# Altium schematic sheet MCU_V2.SchDoc(MCU_V2)
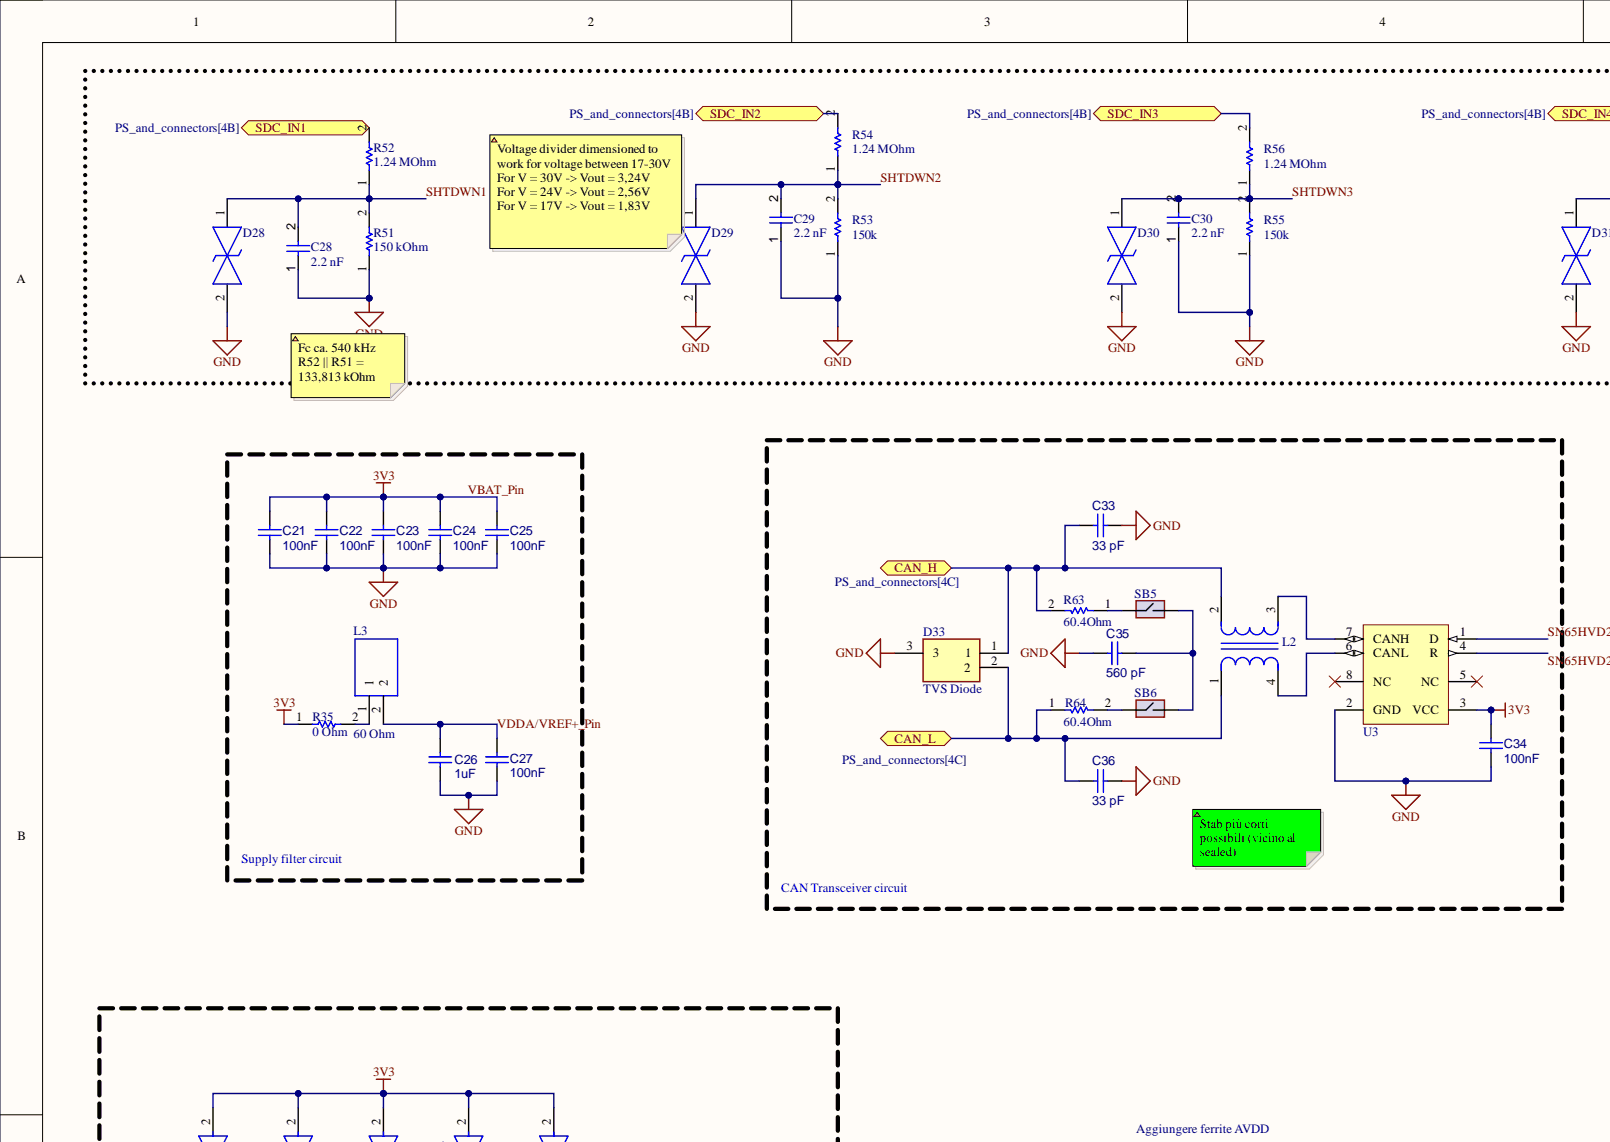
[diagram p0: MCU_V2.SchDoc, top left]
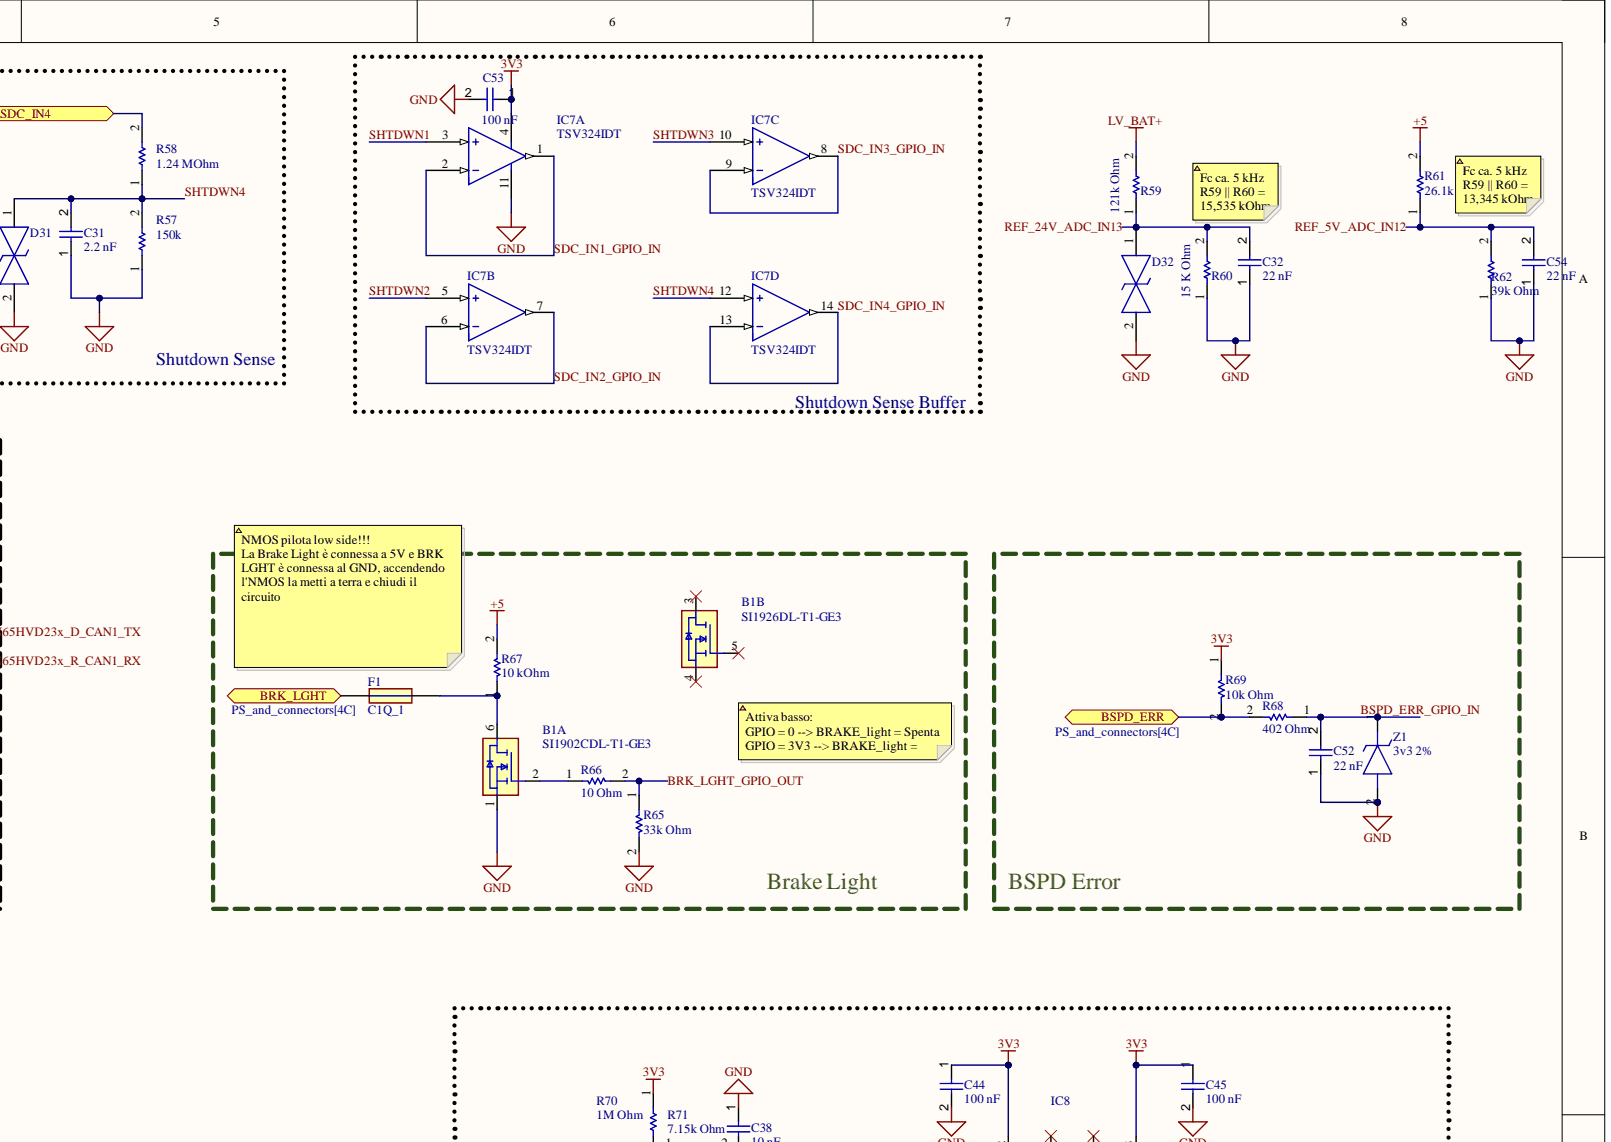
[diagram p1: MCU_V2.SchDoc, top right]
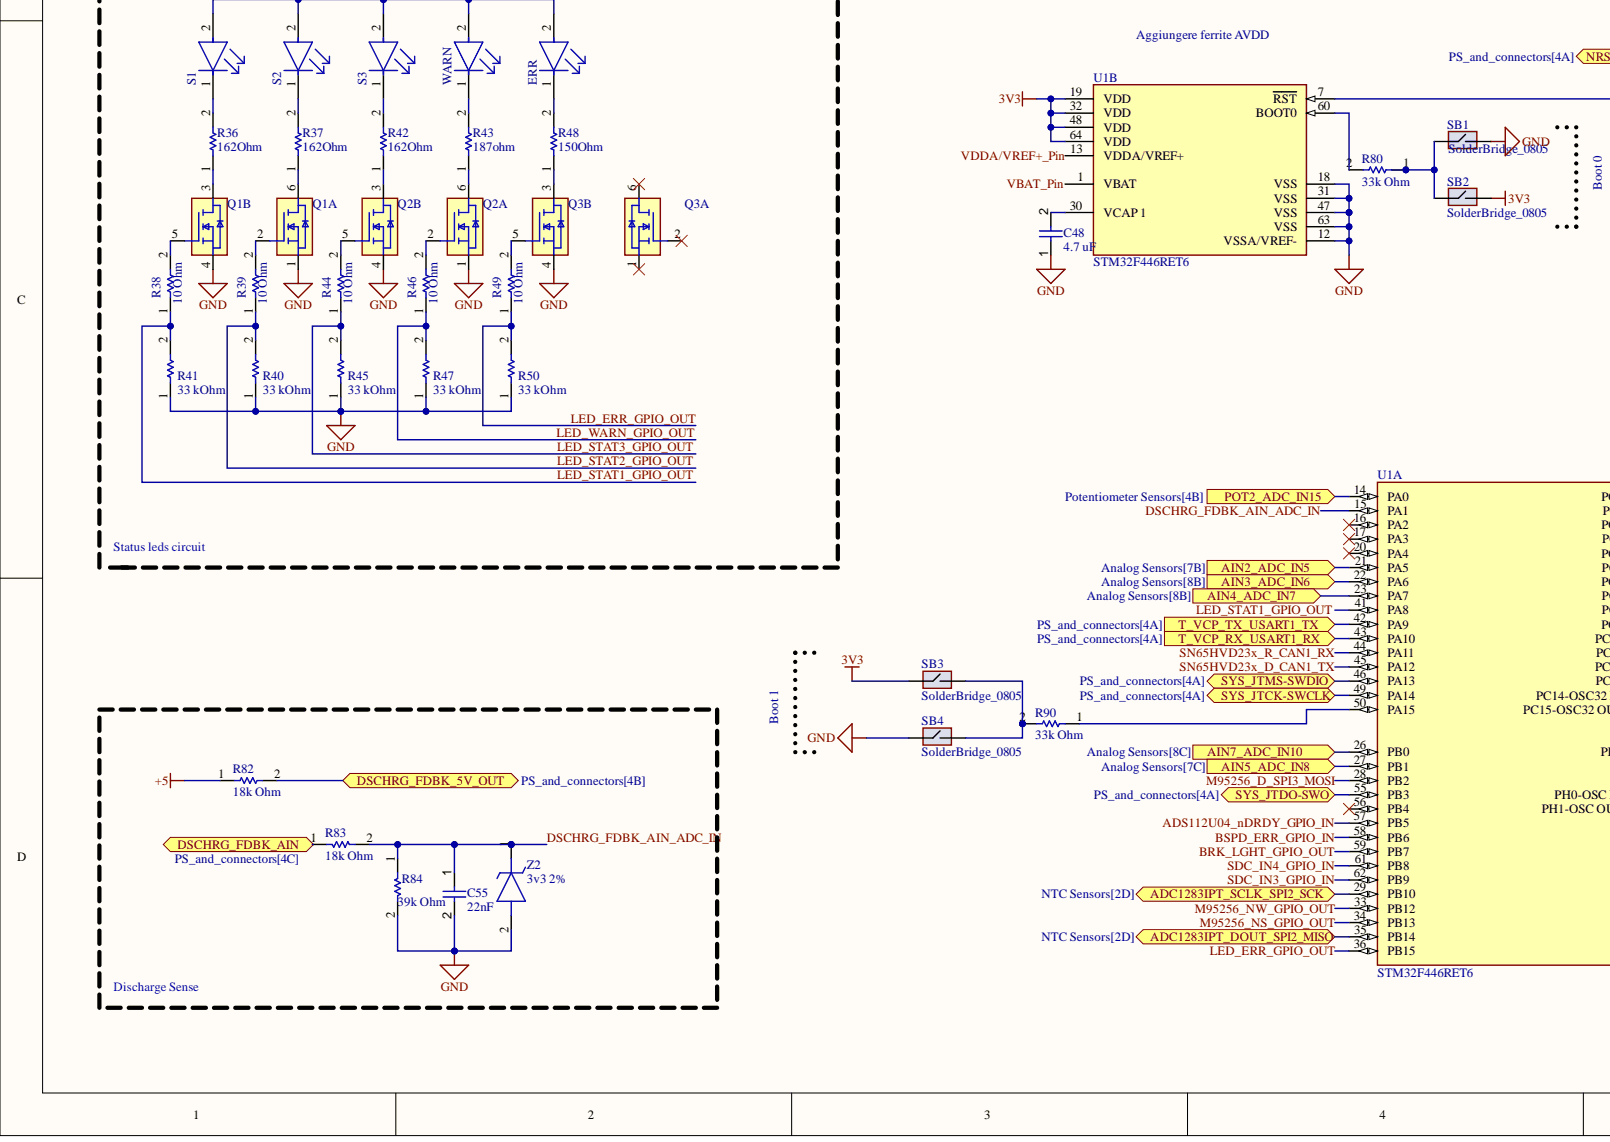
[diagram p2: MCU_V2.SchDoc, bottom left]
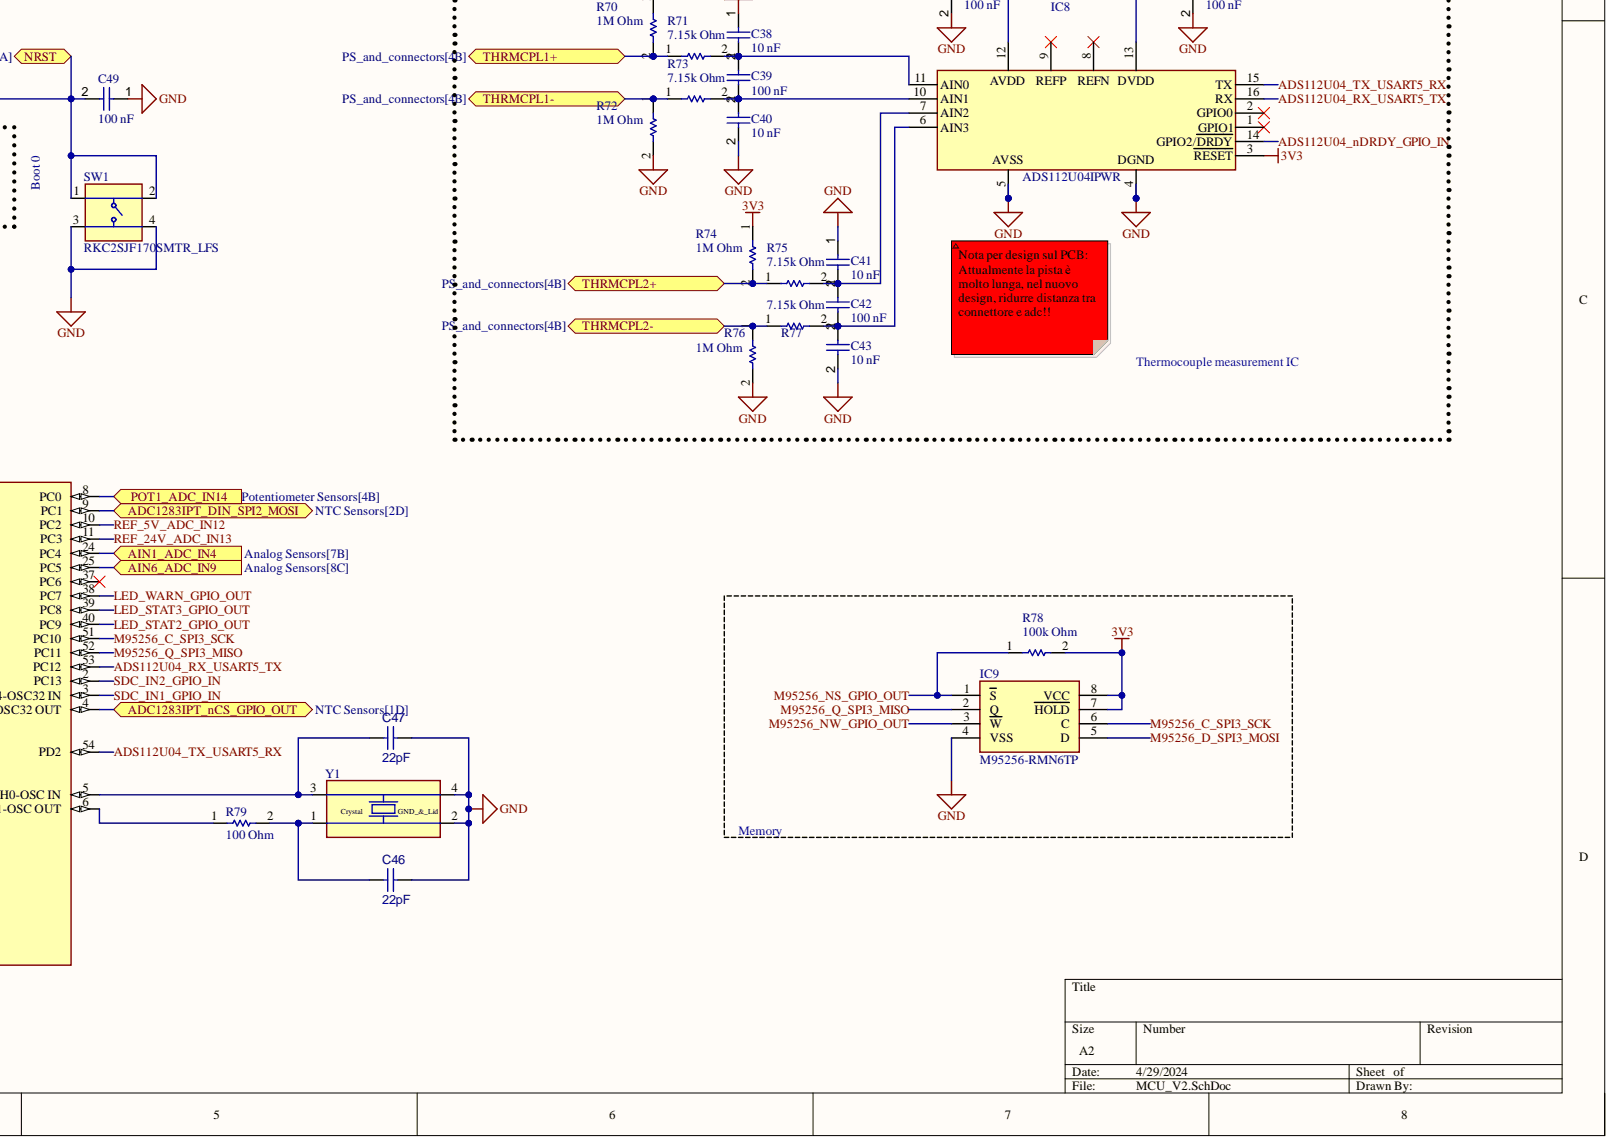
[diagram p3: MCU_V2.SchDoc, bottom right]

## Parts
B1  SI1902CDL-T1-GE3  Arrow Part Number: SI1926DL-T1-GE3  (parts A,B)
C21  100nF
C22  100nF
C23  100nF
C24  100nF
C25  100nF
C26  1uF
C27  100nF
C28  2.2 nF
C29  2.2 nF
C30  2.2 nF
C31  2.2 nF
C32  22 nF
C33  33 pF
C34  100nF
C35  560 pF
C36  33 pF
C38  10 nF
C39  100 nF
C40  10 nF
C41  10 nF
C42  100 nF
C43  10 nF
C44  100 nF
C45  100 nF
C46  22pF
C47  22pF
C48  4.7 uF
C49  100 nF
C52  22 nF
C53  100 nF
C54  22 nF
C55  22nF
D28  SMAJ30CA-TR  (value not drawn)
D29  SMAJ30CA-TR  (value not drawn)
D30  SMAJ30CA-TR  (value not drawn)
D31  SMAJ30CA-TR  (value not drawn)
D32  SMAJ30CA-TR  (value not drawn)
D33  TVS Diode
ERR  RED  (value not drawn)
F1  C1Q_1
IC7  TSV324IDT  (parts A,B,C,D)
IC8  ADS112U04IPWR
IC9  M95256-RMN6TP
L2  ACT45B-101-2P-TL003  (value not drawn)
L3  60 Ohm
Q1  SI1926DL-T1-GE3  (parts A,B)
Q2  SI1926DL-T1-GE3  (parts A,B)
Q3  SI1926DL-T1-GE3  (parts A,B)
R35  0 Ohm
R36  162Ohm
R37  162Ohm
R38  10 Ohm
R39  10 Ohm
R40  33 kOhm
R41  33 kOhm
R42  162Ohm
R43  187ohm
R44  10 Ohm
R45  33 kOhm
R46  10 Ohm
R47  33 kOhm
R48  150Ohm
R49  10 Ohm
R50  33 kOhm
R51  150 kOhm
R52  1.24 MOhm
R53  150k
R54  1.24 MOhm
R55  150k
R56  1.24 MOhm
R57  150k
R58  1.24 MOhm
R59  121k Ohm
R60  15 K Ohm
R61  26.1k Ohm
R62  39k Ohm
R63  60.4Ohm
R64  60.4Ohm
R65  33k Ohm
R66  10 Ohm
R67  10 kOhm
R68  402 Ohm
R69  10k Ohm
R70  1M Ohm
R71  7.15k Ohm
R72  1M Ohm
R73  7.15k Ohm
R74  1M Ohm
R75  7.15k Ohm
R76  1M Ohm
R77  7.15k Ohm
R78  100k Ohm
R79  100 Ohm
R80  33k Ohm
R82  18k Ohm
R83  18k Ohm
R84  39k Ohm
R90  33k Ohm
S1  GREEN  (value not drawn)
S2  GREEN  (value not drawn)
S3  GREEN  (value not drawn)
SB1  SolderBridge_0805  (no BOM)
SB2  SolderBridge_0805  (no BOM)
SB3  SolderBridge_0805  (no BOM)
SB4  SolderBridge_0805  (no BOM)
SB5  SolderBridge_0805  (no BOM)
SB6  SolderBridge_0805  (no BOM)
SW1  RKC2SJF170SMTR_LFS
U1  STM32F446RET6  (parts A,B)
U3  SN65HVD232DRG4  [SN65HVD232]  (value not drawn)
WARN  YELLOW  (value not drawn)
Y1  830055663  (value not drawn)
Z1  3v3 2%
Z2  3v3 2%

## Ports
ADC1283IPT_DIN_SPI2_MOSI, ADC1283IPT_DOUT_SPI2_MISO, ADC1283IPT_nCS_GPIO_OUT, ADC1283IPT_SCLK_SPI2_SCK, AIN1_ADC_IN4, AIN2_ADC_IN5, AIN3_ADC_IN6, AIN4_ADC_IN7, AIN5_ADC_IN8, AIN6_ADC_IN9, AIN7_ADC_IN10, BRK_LGHT, BSPD_ERR, CAN_H, CAN_L, DSCHRG_FDBK_5V_OUT, DSCHRG_FDBK_AIN, NRST, POT1_ADC_IN14, POT2_ADC_IN15, SDC_IN1, SDC_IN2, SDC_IN3, SDC_IN4, SYS_JTCK-SWCLK, SYS_JTDO-SWO, SYS_JTMS-SWDIO, T_VCP_RX_USART1_RX, T_VCP_TX_USART1_TX, THRMCPL1+, THRMCPL1-, THRMCPL2+, THRMCPL2-

## Nets
+5: R61.2 R67.2 R82.1
GND: B1.1 C21.1 C22.1 C23.1 C24.1 C25.1 C26.1 C27.1 C28.1 C29.1 C30.1 C31.1 C32.1 C33.1 C34.2 C35.1 C36.1 C38.1 C40.2 C41.1 C43.2 C44.2 C45.2 C46.2 C47.1 C48.1 C49.1 C52.1 C53.2 C54.1 C55.2 D28.2 D29.2 D30.2 D31.2 D32.2 D33.3 IC7.11 IC8.4 IC8.5 IC9.4(VSS) Q1.1 Q1.4 Q2.1 Q2.4 Q3.4 R40.1 R41.1 R45.1 R47.1 R50.1 R51.1 R53.1 R55.1 R57.1 R60.1 R62.1 R65.2 R72.2 R76.2 R84.2 SB1.2 SB4.1 SW1.3 SW1.4 U1.12(VSSA/VREF-) U1.18(VSS) U1.31(VSS) U1.47(VSS) U1.63(VSS) U3.2(GND) Y1.2 Y1.4 Z1.2 Z2.2
LV_BAT+: R59.2
ADC1283IPT_DIN_SPI2_MOSI: U1.9(PC1) ; port ADC1283IPT_DIN_SPI2_MOSI
ADC1283IPT_DOUT_SPI2_MISO: U1.35(PB14) ; port ADC1283IPT_DOUT_SPI2_MISO
ADC1283IPT_nCS_GPIO_OUT: U1.4(PC15-OSC32_OUT) ; port ADC1283IPT_nCS_GPIO_OUT
ADC1283IPT_SCLK_SPI2_SCK: U1.29(PB10) ; port ADC1283IPT_SCLK_SPI2_SCK
ADS112U04_nDRDY_GPIO_IN: IC8.14(GPIO2/DRDY) U1.57(PB5)
ADS112U04_RX_USART5_TX: IC8.16(RX) U1.53(PC12)
ADS112U04_TX_USART5_RX: IC8.15(TX) U1.54(PD2)
AIN1_ADC_IN4: U1.24(PC4) ; port AIN1_ADC_IN4
AIN2_ADC_IN5: U1.21(PA5) ; port AIN2_ADC_IN5
AIN3_ADC_IN6: U1.22(PA6) ; port AIN3_ADC_IN6
AIN4_ADC_IN7: U1.23(PA7) ; port AIN4_ADC_IN7
AIN5_ADC_IN8: U1.27(PB1) ; port AIN5_ADC_IN8
AIN6_ADC_IN9: U1.25(PC5) ; port AIN6_ADC_IN9
AIN7_ADC_IN10: U1.26(PB0) ; port AIN7_ADC_IN10
BRK_LGHT: F1.2 ; port BRK_LGHT
BRK_LGHT_GPIO_OUT: R65.1 R66.2 U1.59(PB7)
BSPD_ERR: R68.2 R69.2 ; port BSPD_ERR
BSPD_ERR_GPIO_IN: C52.2 R68.1 U1.58(PB6) Z1.1
CAN_H: C33.2 D33.1 L2.2 R63.2 ; port CAN_H
CAN_L: C36.2 D33.2 L2.1 R64.1 ; port CAN_L
DSCHRG_FDBK_5V_OUT: R82.2 ; port DSCHRG_FDBK_5V_OUT
DSCHRG_FDBK_AIN: R83.1 ; port DSCHRG_FDBK_AIN
DSCHRG_FDBK_AIN_ADC_IN: C55.1 R83.2 R84.1 U1.15(PA1) Z2.1
LED_ERR_GPIO_OUT: R49.1 R50.2 U1.36(PB15)
LED_STAT1_GPIO_OUT: R38.1 R41.2 U1.41(PA8)
LED_STAT2_GPIO_OUT: R39.1 R40.2 U1.40(PC9)
LED_STAT3_GPIO_OUT: R44.1 R45.2 U1.39(PC8)
LED_WARN_GPIO_OUT: R46.1 R47.2 U1.38(PC7)
M95256_C_SPI3_SCK: IC9.6(C) U1.51(PC10)
M95256_D_SPI3_MOSI: IC9.5(D) U1.28(PB2)
M95256_NS_GPIO_OUT: IC9.1(S) R78.1 U1.34(PB13)
M95256_NW_GPIO_OUT: IC9.3(W) U1.33(PB12)
M95256_Q_SPI3_MISO: IC9.2(Q) U1.52(PC11)
NRST: C49.2 SW1.1 SW1.2 U1.7(RST) ; port NRST
POT1_ADC_IN14: U1.8(PC0) ; port POT1_ADC_IN14
POT2_ADC_IN15: U1.14(PA0) ; port POT2_ADC_IN15
REF_5V_ADC_IN12: C54.2 R61.1 R62.2 U1.10(PC2)
REF_24V_ADC_IN13: C32.2 D32.1 R59.1 R60.2 U1.11(PC3)
SDC_IN1: R52.2 ; port SDC_IN1
SDC_IN1_GPIO_IN: IC7.1 IC7.2 U1.3(PC14-OSC32_IN)
SDC_IN2: R54.2 ; port SDC_IN2
SDC_IN2_GPIO_IN: IC7.6 IC7.7 U1.2(PC13)
SDC_IN3: R56.2 ; port SDC_IN3
SDC_IN3_GPIO_IN: IC7.8 IC7.9 U1.62(PB9)
SDC_IN4: R58.2 ; port SDC_IN4
SDC_IN4_GPIO_IN: IC7.13 IC7.14 U1.61(PB8)
SHTDWN1: C28.2 D28.1 IC7.3 R51.2 R52.1
SHTDWN2: C29.2 D29.1 IC7.5 R53.2 R54.1
SHTDWN3: C30.2 D30.1 IC7.10 R55.2 R56.1
SHTDWN4: C31.2 D31.1 IC7.12 R57.2 R58.1
SN65HVD23x_D_CAN1_TX: U1.45(PA12) U3.1(D)
SN65HVD23x_R_CAN1_RX: U1.44(PA11) U3.4(R)
SYS_JTCK-SWCLK: U1.49(PA14) ; port SYS_JTCK-SWCLK
SYS_JTDO-SWO: U1.55(PB3) ; port SYS_JTDO-SWO
SYS_JTMS-SWDIO: U1.46(PA13) ; port SYS_JTMS-SWDIO
T_VCP_RX_USART1_RX: U1.43(PA10) ; port T_VCP_RX_USART1_RX
T_VCP_TX_USART1_TX: U1.42(PA9) ; port T_VCP_TX_USART1_TX
VBAT_Pin: C21.2 C22.2 C23.2 C24.2 C25.2 C34.1 C44.1 C45.1 C53.1 ERR.2 IC7.4 IC8.3(RESET) IC8.12 IC8.13 IC9.7(HOLD) IC9.8(VCC) R35.1 R69.1 R70.1 R74.1 R78.2 S1.2 S2.2 S3.2 SB2.2 SB3.1 U1.1(VBAT) U1.19(VDD) U1.32(VDD) U1.48(VDD) U1.64(VDD) U3.3(VCC) WARN.2
VDDA/VREF+_Pin: C26.2 C27.2 L3.2 U1.13(VDDA/VREF+)
NetB1_2: B1.2 R66.1
NetB1_6: B1.6 F1.1 R67.1
NetC35_2: C35.2 SB5.2 SB6.2
NetC38_2: C38.2 C39.1 IC8.11(AIN0) R71.2
NetC39_2: C39.2 C40.1 IC8.10(AIN1) R73.2
NetC41_2: C41.2 C42.1 IC8.7(AIN2) R75.2
NetC42_2: C42.2 C43.1 IC8.6(AIN3) R77.2
NetC46_1: C46.1 R79.2 Y1.1
NetC47_2: C47.2 U1.5(PH0-OSC_IN) Y1.3
NetC48_2: C48.2 U1.30(VCAP_1)
NetERR_1: ERR.1 R48.2
NetL2_3: L2.3 U3.7(CANH)
NetL2_4: L2.4 U3.6(CANL)
NetL3_1: L3.1 R35.2
NetQ1_2: Q1.2 R39.2
NetQ1_3: Q1.3 R36.1
NetQ1_5: Q1.5 R38.2
NetQ1_6: Q1.6 R37.1
NetQ2_2: Q2.2 R46.2
NetQ2_3: Q2.3 R42.1
NetQ2_5: Q2.5 R44.2
NetQ2_6: Q2.6 R43.1
NetQ3_3: Q3.3 R48.1
NetQ3_5: Q3.5 R49.2
NetR36_2: R36.2 S1.1
NetR37_2: R37.2 S2.1
NetR42_2: R42.2 S3.1
NetR43_2: R43.2 WARN.1
NetR63_1: R63.1 SB5.1
NetR64_2: R64.2 SB6.1
NetR70_2: R70.2 R71.1
NetR72_1: R72.1 R73.1
NetR74_2: R74.2 R75.1
NetR76_1: R76.1 R77.1
NetR79_1: R79.1 U1.6(PH1-OSC_OUT)
NetR80_1: R80.1 SB1.1 SB2.1
NetR80_2: R80.2 U1.60(BOOT0)
NetR90_1: R90.1 U1.50(PA15)
NetR90_2: R90.2 SB3.2 SB4.2

Not connected: B1 3 4 5; IC8 1(GPIO1) 2(GPIO0) 8 9; Q3 1 2 6; U1 16(PA2) 17(PA3) 20(PA4) 37(PC6) 56(PB4); U3 5(NC) 8(NC)

## Library data of the parts
B1 Q1-Q3 = CMP-009-000004-2: MOSFET (N-Channel); Manufacturer_Name: Vishay; Arrow Price/Stock: https://www.arrow.com/en/products/si1926dl-t1-ge3/vishay; Component Kind: Standard; Datasheet Link: https://www.vishay.com/docs/73684/si1926dl.pdf; Design Item ID: SI1926DL-T1-GE3; Height: 1.1mm; Manufacturer_Part_Number: SI1926DL-T1-GE3; Mouser Part Number: 78-SI1926DL-T1-GE3; Mouser Price/Stock: https://www.mouser.com/Search/Refine.aspx?Keyword=78-SI1926DL-T1-GE3; Source: SamacSys.SchLib; Library Name: EMEA- Squadra Corse PoliTO-  Politecnico di Torino
  Q1-Q3: Arrow Part Number: SI1926DL-T1-GE3
C21-C25 C27 = CMP-001-00085-5: VJ0805Y104KBABE31; Tech: SMD; Component Kind: Standard; ComponentLink1Description: Website; Package: 0805; Library Name: EMEA- Squadra Corse PoliTO-  Politecnico di Torino
C26 = CMP-001-00085-5: VJ0805Y105KXXTW1BC; Tech: SMD; Component Kind: Standard; ComponentLink1Description: Website; Package: 0805; Library Name: EMEA- Squadra Corse PoliTO-  Politecnico di Torino
C28-C31 = CMP-00009-1: 77-VJ0805Y222KXBAC; Component Kind: Standard; Library Name: EMEA- Squadra Corse PoliTO-  Politecnico di Torino
C32 C52 C54 C55 = CMP-00009-1: VJ0805Y223JXXCW1BC; Component Kind: Standard; Library Name: EMEA- Squadra Corse PoliTO-  Politecnico di Torino
C33 C36 = CMP-001-00085-5: VJ0805A330JXACW1BC; Tech: SMD; Component Kind: Standard; ComponentLink1Description: Website; Package: 0805; Library Name: EMEA- Squadra Corse PoliTO-  Politecnico di Torino
C34 = CMP-001-00085-5: VJ0805Y104MXATW1BC; Tech: SMD; Component Kind: Standard; ComponentLink1Description: Website; Package: 0805; Library Name: EMEA- Squadra Corse PoliTO-  Politecnico di Torino
C35 = CMP-001-00085-5: VJ0805Y561JXXPW1BC; Tech: SMD; Component Kind: Standard; ComponentLink1Description: Website; Package: 0805; Library Name: EMEA- Squadra Corse PoliTO-  Politecnico di Torino
C38 C40 C41 C43 = CMP-00009-1: VJ0805H103KXBBC31; Component Kind: Standard; Library Name: EMEA- Squadra Corse PoliTO-  Politecnico di Torino
C39 C42 C44 C45 C49 C53 = CMP-00009-1: VJ0805Y104MXATW1BC; Component Kind: Standard; Library Name: EMEA- Squadra Corse PoliTO-  Politecnico di Torino
C46 C47 = CMP-001-00085-5: VJ0805A220KXACW1BC; Tech: SMD; Component Kind: Standard; ComponentLink1Description: Website; Package: 0805; Library Name: EMEA- Squadra Corse PoliTO-  Politecnico di Torino
C48 = CMP-00009-1: GRM21BR71C475KE51K; Component Kind: Standard; Library Name: EMEA- Squadra Corse PoliTO-  Politecnico di Torino
D28-D32 = CMP-004-000005-1: TVS Diode Bi-Directional SMAJ30CA-TR 64.3V; Manufacturer_Name: STMicroelectronics; Arrow Part Number: SMAJ30CA-TR; Arrow Price/Stock: https://www.arrow.com/en/products/smaj30ca-tr/stmicroelectronics?region=nac; Component Kind: Standard; Datasheet Link: https://www.st.com/resource/en/datasheet/smaj10ca.pdf; Design Item ID: SMAJ30CA-TR; Height: 2.65mm; Manufacturer_Part_Number: SMAJ30CA-TR; Mouser Part Number: 511-SMAJ30CA-TR; Mouser Price/Stock: https://www.mouser.co.uk/ProductDetail/STMicroelectronics/SMAJ30CA-TR?qs=0TJjK1waviDY0EkLaKH12g%3D%3D; Source: SamacSys.SchLib; Library Name: EMEA- Squadra Corse PoliTO-  Politecnico di Torino
D33 = CMP-030-000036-3: ESDCAN24-2BLY; Tech: SMD; Component Kind: Standard; Package: SOT-23-3; Library Name: EMEA- Squadra Corse PoliTO-  Politecnico di Torino
ERR = CMP-007-00036-2: VLMS1300-GS08; Tech: SMD; Component Kind: Standard; Package: 0603; Library Name: EMEA- Squadra Corse PoliTO-  Politecnico di Torino
F1 = CMP-002-000065-1: operating current BRK-LGHT is 120mA 600mW; Manufacturer_Name: Bel; Manufacturer_Part_Number: C1Q 1; Geometry.Height: 0.78mm; Component Kind: Standard; Datasheet Link: https://www.mouser.com/datasheet/2/643/ds-CP-c1q-series-1313102.pdf; Design Item ID: C1Q_1; Mouser Part Number: 530-C1Q1; Source: C1Q_1.SchLib; Library Name: EMEA- Squadra Corse PoliTO-  Politecnico di Torino
IC7 = CMP-007-000004-4: Manufacturer_Name: STMicroelectronics; Arrow Part Number: TSV324IDT; Arrow Price/Stock: https://www.arrow.com/en/products/tsv324idt/stmicroelectronics; Component Kind: Standard; Datasheet Link: http://www.st.com/st-web-ui/static/active/en/resource/technical/document/datasheet/CD00063292.pdf; Description: TSV324IDT; Design Item ID: TSV324IDT; Height: 1.75mm; Manufacturer_Part_Number: TSV324IDT; Mouser Part Number: 511-TSV324IDT; Mouser Price/Stock: https://www.mouser.com/Search/Refine.aspx?Keyword=511-TSV324IDT; Source: SamacSys.SchLib; Library Name: EMEA- Squadra Corse PoliTO-  Politecnico di Torino
IC8 = CMP-007-000008-2: 16-Bit; Manufacturer_Name: Texas Instruments; Arrow Part Number: ADS112U04IPWR; Arrow Price/Stock: https://www.arrow.com/en/products/ads112u04ipwr/texas-instruments?region=nac; Component Kind: Standard; Datasheet Link: http://www.ti.com/lit/gpn/ads112u04; Design Item ID: ADS112U04IPWR; Height: 1.2mm; Manufacturer_Part_Number: ADS112U04IPWR; Mouser Part Number: 595-ADS112U04IPWR; Mouser Price/Stock: https://www.mouser.co.uk/ProductDetail/Texas-Instruments/ADS112U04IPWR?qs=rrS6PyfT74fPyJ78HLCbmA%3D%3D; Source: SamacSys.SchLib; Library Name: EMEA- Squadra Corse PoliTO-  Politecnico di Torino
IC9 = CMP-007-000006-1: 256-Kbit serial SPI bus EEPROM with high-speed clock; Manufacturer_Name: STMicroelectronics; Arrow Part Number: M95256-RMN6TP; Arrow Price/Stock: https://www.arrow.com/en/products/m95256-rmn6tp/stmicroelectronics; Component Kind: Standard; Datasheet Link: https://datasheet.lcsc.com/szlcsc/1812011607_STMicroelectronics-M95256-RMN6TP_C140883.pdf; Design Item ID: M95256-RMN6TP; Height: 1.75mm; Manufacturer_Part_Number: M95256-RMN6TP; Mouser Part Number: 511-M95256-RMN6TP; Mouser Price/Stock: https://www.mouser.co.uk/ProductDetail/STMicroelectronics/M95256-RMN6TP?qs=6hSH1YZbOIgt2lfjA1S%252B0g%3D%3D; Source: SamacSys.SchLib; Library Name: EMEA- Squadra Corse PoliTO-  Politecnico di Torino
L2 = CMP-08256-000007-1: CMC 100UH 150MA 2LN SMD AEC-Q200; Manufacturer: TDK; Automotive Grade: Grade 0; Impedance At Frequency: 5800Ω @ 10MHz; Rms Current: 0.15A; Series Resistance: 2Ω; Test Frequency: 100kHz; Tolerance: +50/-30%; Automotive: Yes; Category: Ind; Component Kind: Standard; ComponentLink2Description: Datasheet; ComponentLink2URL: https://product.tdk.com/info/en/catalog/datasheets/cmf_automotive_signal_act45b_en.pdf; Composition: Wirewound; Device Class L1: Passive Components; Device Class L2: EMI / RFI Components; Device Class L3: Common Mode Chokes; Digikey Description: CMC 100UH 150MA 2LN SMD AEC-Q200; Height: 3mm; Inductance: 100uH; Lead Free: Yes; Library Name: Altium Content Vault; Manufacturer Part Number: ACT45B-101-2P-TL003; Mouser Description: Common Mode Chokes / Filters 5000ohms 100uH 150mA CAN-BUS AEC-Q200; Octopart: https://octopart.com/act45b-101-2p-tl003-tdk-11353565; Package: ACT45B; RoHS: TRUE; Temperature: -40°C to +150°C; Temperature Range High: +150°C; Temperature Range Low: -40°C; Value: 100uH
L3 = CMP-056-000000-2: Ferrite Beads Multi-Layer High Current 60Ohm 25% 100MHz 3A 30mOhm DCR 0805 T/R; Manufacturer_Name: Vishay; Manufacturer_Part_Number: ILHB0805ER600V; Geometry.Height: 1.1mm; Component Kind: Standard; Datasheet Link: https://www.arrow.com/en/products/ilhb0805er600v/vishay; Design Item ID: ILHB0805ER600V; Mouser Part Number: 70-ILHB0805ER600V; Mouser Price/Stock: https://www.mouser.co.uk/ProductDetail/Vishay-Dale/ILHB0805ER600V?qs=nDC8RkArV2CRAw2z9yWdfQ%3D%3D; Source: ILHB0805ER600V.SchLib; Library Name: EMEA- Squadra Corse PoliTO-  Politecnico di Torino
R35 = CMP-009-00179-5: CRCW12060000Z0EC; Tech: SMD; Component Kind: Standard; ComponentLink1Description: Website; Package: 0603; Library Name: EMEA- Squadra Corse PoliTO-  Politecnico di Torino
R36 R37 R42 = CMP-009-00179-5: CRCW0603162RFKEA; Tech: SMD; Component Kind: Standard; ComponentLink1Description: Website; Package: 0603; Library Name: EMEA- Squadra Corse PoliTO-  Politecnico di Torino
R38 R39 R44 R46 R49 = CMP-009-00179-5: CRCW060310R0FKEAHP; Tech: SMD; Component Kind: Standard; ComponentLink1Description: Website; Package: 0603; Library Name: EMEA- Squadra Corse PoliTO-  Politecnico di Torino
R40 R41 R45 R47 R50 R65 R84 R90 = CMP-009-00179-5: RCS060333K0FKEA; Tech: SMD; Component Kind: Standard; ComponentLink1Description: Website; Package: 0603; Library Name: EMEA- Squadra Corse PoliTO-  Politecnico di Torino
R43 = CMP-009-00179-5: CRCW0603187RFKEA; Tech: SMD; Component Kind: Standard; ComponentLink1Description: Website; Package: 0603; Library Name: EMEA- Squadra Corse PoliTO-  Politecnico di Torino
R48 = CMP-009-00179-5: CRCW0603150RFKEAHP; Tech: SMD; Component Kind: Standard; ComponentLink1Description: Website; Package: 0603; Library Name: EMEA- Squadra Corse PoliTO-  Politecnico di Torino
R51 = CMP-00010-3: MCT06030D1503BP100; Component Kind: Standard; Library Name: EMEA- Squadra Corse PoliTO-  Politecnico di Torino
R52 = CMP-00010-3: CRCW06031M24FKEA; Component Kind: Standard; Library Name: EMEA- Squadra Corse PoliTO-  Politecnico di Torino
R53 R55 R57 = CMP-009-00179-5: MCT06030D1503BP100; Tech: SMD; Component Kind: Standard; ComponentLink1Description: Website; Package: 0603; Library Name: EMEA- Squadra Corse PoliTO-  Politecnico di Torino
R54 R56 R58 = CMP-009-00179-5: CRCW06031M24FKEA; Tech: SMD; Component Kind: Standard; ComponentLink1Description: Website; Package: 0603; Library Name: EMEA- Squadra Corse PoliTO-  Politecnico di Torino
R59 = CMP-009-00179-5: CRCW0603121KFKEB; Tech: SMD; Component Kind: Standard; ComponentLink1Description: Website; Package: 0603; Library Name: EMEA- Squadra Corse PoliTO-  Politecnico di Torino
R60 = CMP-009-00179-5: CRCW060315K0FKEA; Tech: SMD; Component Kind: Standard; ComponentLink1Description: Website; Package: 0603; Library Name: EMEA- Squadra Corse PoliTO-  Politecnico di Torino
R61 = CMP-009-00179-5: RT0603BRD0726K1L; Tech: SMD; Component Kind: Standard; ComponentLink1Description: Website; Package: 0603; Library Name: EMEA- Squadra Corse PoliTO-  Politecnico di Torino
R62 = CMP-009-00179-5: Footprint RT0603BRD0739KL0603; Tech: SMD; Component Kind: Standard; ComponentLink1Description: Website; Package: 0603; Library Name: EMEA- Squadra Corse PoliTO-  Politecnico di Torino
R63 R64 = CMP-009-00179-5: CRCW060360R4FKEA; Tech: SMD; Component Kind: Standard; ComponentLink1Description: Website; Package: 0603; Library Name: EMEA- Squadra Corse PoliTO-  Politecnico di Torino
R66 = CMP-009-00179-5: FootprintRC0603FR-7W10RL 0603; Tech: SMD; Component Kind: Standard; ComponentLink1Description: Website; Package: 0603; Library Name: EMEA- Squadra Corse PoliTO-  Politecnico di Torino
R67 = CMP-00010-3: General Resistor 0603; Component Kind: Standard; Library Name: EMEA- Squadra Corse PoliTO-  Politecnico di Torino
R68 = CMP-009-00179-5: CRCW0603402RFKEA; Tech: SMD; Component Kind: Standard; ComponentLink1Description: Website; Package: 0603; Library Name: EMEA- Squadra Corse PoliTO-  Politecnico di Torino
R69 = CMP-009-00179-5: RC1206FR-0710KL; Tech: SMD; Component Kind: Standard; ComponentLink1Description: Website; Package: 0603; Library Name: EMEA- Squadra Corse PoliTO-  Politecnico di Torino
R70 R72 R74 R76 = CMP-009-00179-5: AC0603FR-071ML; Tech: SMD; Component Kind: Standard; ComponentLink1Description: Website; Package: 0603; Library Name: EMEA- Squadra Corse PoliTO-  Politecnico di Torino
R71 R73 R75 R77 = CMP-009-00179-5: AC0603FR-077K15L; Tech: SMD; Component Kind: Standard; ComponentLink1Description: Website; Package: 0603; Library Name: EMEA- Squadra Corse PoliTO-  Politecnico di Torino
R78 = CMP-009-00179-5: RT0603FRE13100KL; Tech: SMD; Component Kind: Standard; ComponentLink1Description: Website; Package: 0603; Library Name: EMEA- Squadra Corse PoliTO-  Politecnico di Torino
R79 R80 = CMP-009-00179-5: CRCW060320R0FKEAC; Tech: SMD; Component Kind: Standard; ComponentLink1Description: Website; Package: 0603; Library Name: EMEA- Squadra Corse PoliTO-  Politecnico di Torino
R82 R83 = CMP-009-00179-5: RCS060318K0FKEA; Tech: SMD; Component Kind: Standard; ComponentLink1Description: Website; Package: 0603; Library Name: EMEA- Squadra Corse PoliTO-  Politecnico di Torino
S1-S3 = CMP-007-00036-2: VLMTG1401-GS08; Tech: SMD; Component Kind: Standard; Package: 0603; Library Name: EMEA- Squadra Corse PoliTO-  Politecnico di Torino
SB1-SB6 = CMP-00006-5: 0805 Solder Bridge; Library Name: EMEA- Squadra Corse PoliTO-  Politecnico di Torino
SW1 = CMP-011-000004-5: Tactile Switches; Manufacturer_Name: C & K COMPONENTS; Manufacturer_Part_Number: RKC2SJF170SMTR LFS; Arrow Part Number: RKC2SJF170SMTR LFS; Arrow Price/Stock: https://www.arrow.com/en/products/rkc2sjf170smtr-lfs/ck?region=nac; Component Kind: Standard; Datasheet Link: https://www.ckswitches.com/media/3470/ck_rkc2_datasheet.pdf; Design Item ID: RKC2SJF170SMTR_LFS; Height: 1.9mm; Mouser Part Number: 611-RKC2SJF170SMRLFS; Mouser Price/Stock: https://www.mouser.co.uk/ProductDetail/CK/RKC2SJF170SMTR-LFS?qs=XAiT9M5g4x%252BRRI9derenng%3D%3D; Source: SamacSys.SchLib; Library Name: EMEA- Squadra Corse PoliTO-  Politecnico di Torino
U1 = CMP-12105-000007-1: IC MCU 32BIT 512KB FLASH 64LQFP; Manufacturer: ST; Core Architecture: ARM Cortex-M4; Data Bus Width: 32bits; Frequency: 180MHz; Interface: I2C,SPI,UART,USART,CAN,USB,LIN,IrDA,I2S,Other; Max Junction Temp: +105°C; Max Supply Voltage: 3.6V; Memory Size: 512KBytes; Min Supply Voltage: 1.7V; Number Of A/D Converters: 3; Number Of Adc Channels: 16; Number Of Cores: 1; Number Of I2C Channels: 4; Number Of I/Os: 50; Number Of Pwm Channels: 32; Number Of Timers/Counters: 14; Number Of Uart Channels: 6; Number Of Usb Channels: 2; Ram Size: 128KBytes; Standoff Height: 0.05mm; Ambient Temperature Range High: +85°C; Ambient Temperature Range Low: -40°C; Automotive: No; Category: IC; Component Kind: Standard; ComponentLink2Description: Datasheet; ComponentLink2URL: https://www.st.com/en/microcontrollers-microprocessors/stm32f446re.html; Device Class L1: Integrated Circuits (ICs); Device Class L2: Embedded Processors and Controllers; Device Class L3: Microcontrollers; Digikey Description: IC MCU 32BIT 512KB FLASH 64LQFP; Height: 1.6mm; Ipc Land Pattern Name: QFP50P1200X1200X160-64; Lead Free: Yes; Library Name: Altium Content Vault; Manufacturer Part Number: STM32F446RET6; Memory Type: FLASH; Mouser Description: ARM Microcontrollers - MCU High-performance and DSP with FP, ARM Cortex-M4 MCU with 512 Kbytes Flash, 180 MHz CPU, Art Accelerator, SDRAM; Number Of Spi Channels: 4; Octopart: https://octopart.com/stm32f446ret6-stmicroelectronics-52642920; Package: LQFP64-5W; RoHS: TRUE; Temperature: -40°C to +105°C; Temperature Range High: +105°C; Temperature Range Low: -40°C
U3 = CMP-0315-00080-1: 3.3 V Can Transceiver, 17 mA, -40 to 85 degC, 8-pin SOIC (D), Green (RoHS & no Sb/Br); Manufacturer: Texas Instruments; Drivers Per Package: 1; ICC(Max)(mA): 17; Rating: Catalog; Supply Voltage(s)(V): 3.3; Transient Pulse Protection(V): -25 to 25; Code_JEDEC: MS-012AA; Component Kind: Standard; ComponentLink1Description: Datasheet; ComponentLink1URL: http://www.ti.com/general/docs/lit/getliterature.tsp?genericPartNumber=SN65HVD232&fileType=pdf; DatasheetVersion: SLOS346K; Eco-Plan: Green (RoHS & no Sb/Br); Isolated: No; Library Name: Altium Content Vault; Mounting Technology: Surface Mount; PackageDescription: 8-Pin Plastic Small Outline Integrated Circuit, 4.9 x 3.9 mm, Pitch 1.27 mm Pitch; PackageReference: D8; PackageVersion: revM, Jun-2011; PartNumber: SN65HVD232DRG4; Receivers Per Package: 1; SubFamily: CAN
WARN = CMP-007-00036-2: VLMG1300-GS08; Tech: SMD; Component Kind: Standard; Package: 0603; Library Name: EMEA- Squadra Corse PoliTO-  Politecnico di Torino
Y1 = CMP-004-000011-5: Crystals WE-XTAL 8.0MHz 30ppm 5.0 x 3.2mm; Manufacturer_Name: Wurth Elektronik; Component Kind: Standard; Datasheet Link: https://www.we-online.com/catalog/datasheet/830055663.pdf; Design Item ID: 830055663; Height: 1mm; Manufacturer_Part_Number: 830055663; Mouser Part Number: 710-830055663; Mouser Price/Stock: https://www.mouser.co.uk/ProductDetail/Wurth-Elektronik/830055663?qs=2WXlatMagcHXGqDkS%2FTHKQ%3D%3D; Source: SamacSys.SchLib; Library Name: EMEA- Squadra Corse PoliTO-  Politecnico di Torino
Z1 Z2 = CMP-011-000001-1: MMSZ5226C-HE3-08; Manufacturer_Name: Vishay; Arrow Part Number: MMSZ5226C-HE3-08; Arrow Price/Stock: https://www.arrow.com/en/products/mmsz5226c-he3-08/vishay?region=nac; Component Kind: Standard; Datasheet Link: https://www.arrow.com/en/products/mmsz5226c-he3-08/vishay; Design Item ID: MMSZ5226C-HE3-08; Height: 1.35mm; Manufacturer_Part_Number: MMSZ5226C-HE3-08; Mouser Part Number: 78-MMSZ5226C-HE3-08; Mouser Price/Stock: https://www.mouser.co.uk/ProductDetail/Vishay-Semiconductors/MMSZ5226C-HE3-08?qs=ESFF34UzhwgdfTXz%2Fhjhlg%3D%3D; Source: SamacSys.SchLib; Library Name: EMEA- Squadra Corse PoliTO-  Politecnico di Torino
